# Altium schematic sheet Sheet1.SchDoc(Sheet1)
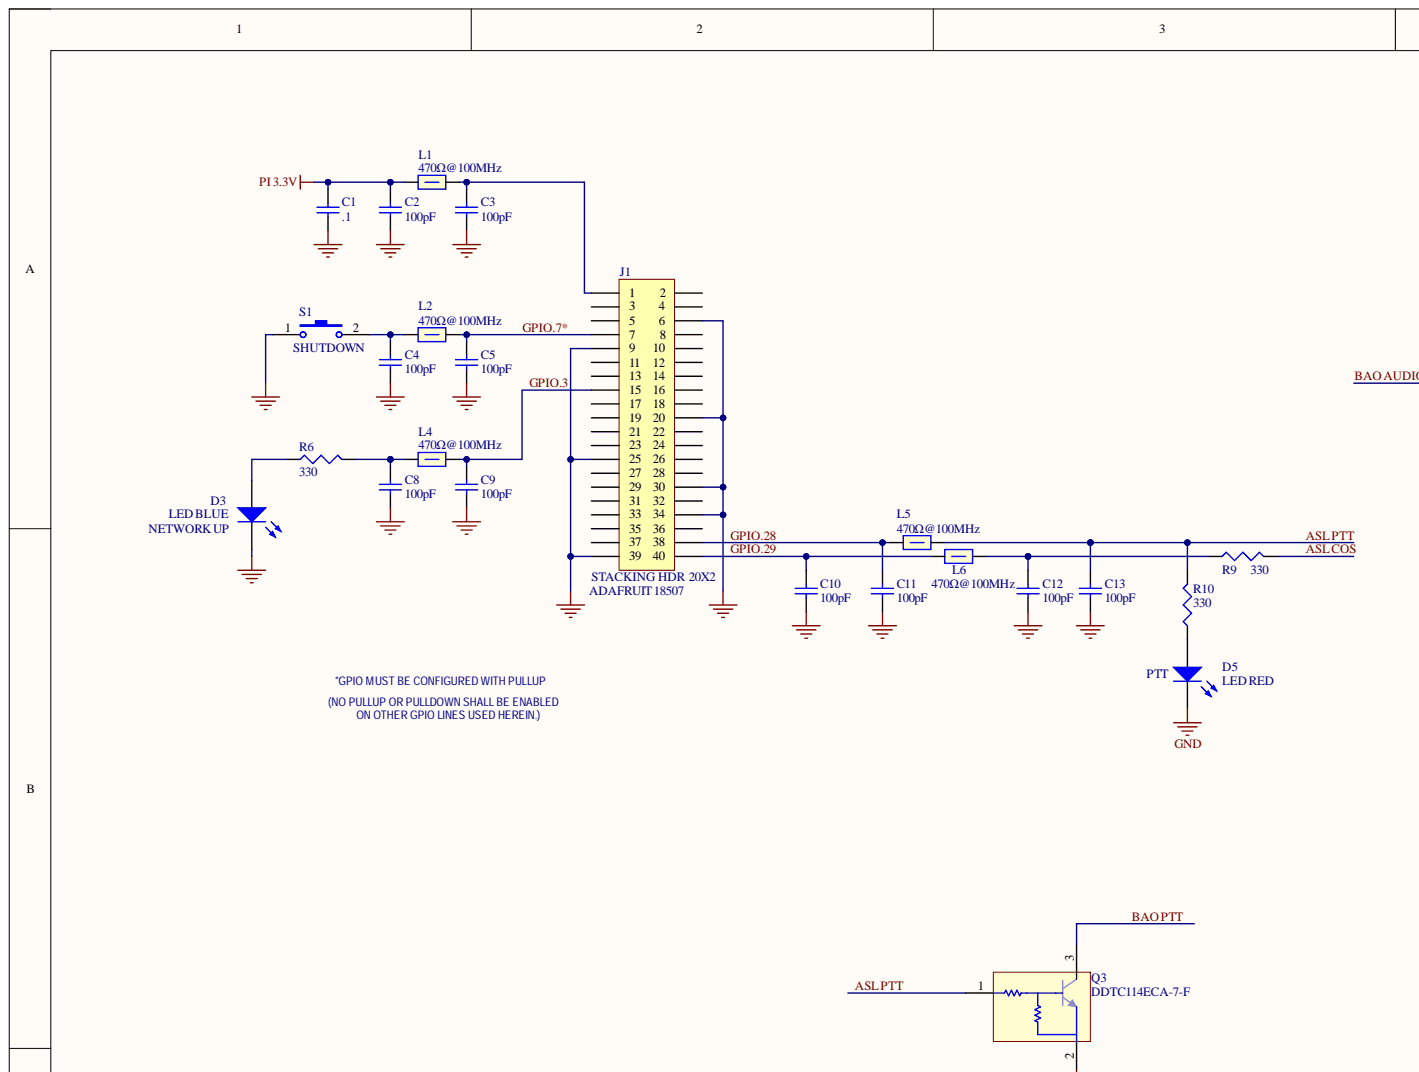
[diagram p0: Sheet1.SchDoc, top left]
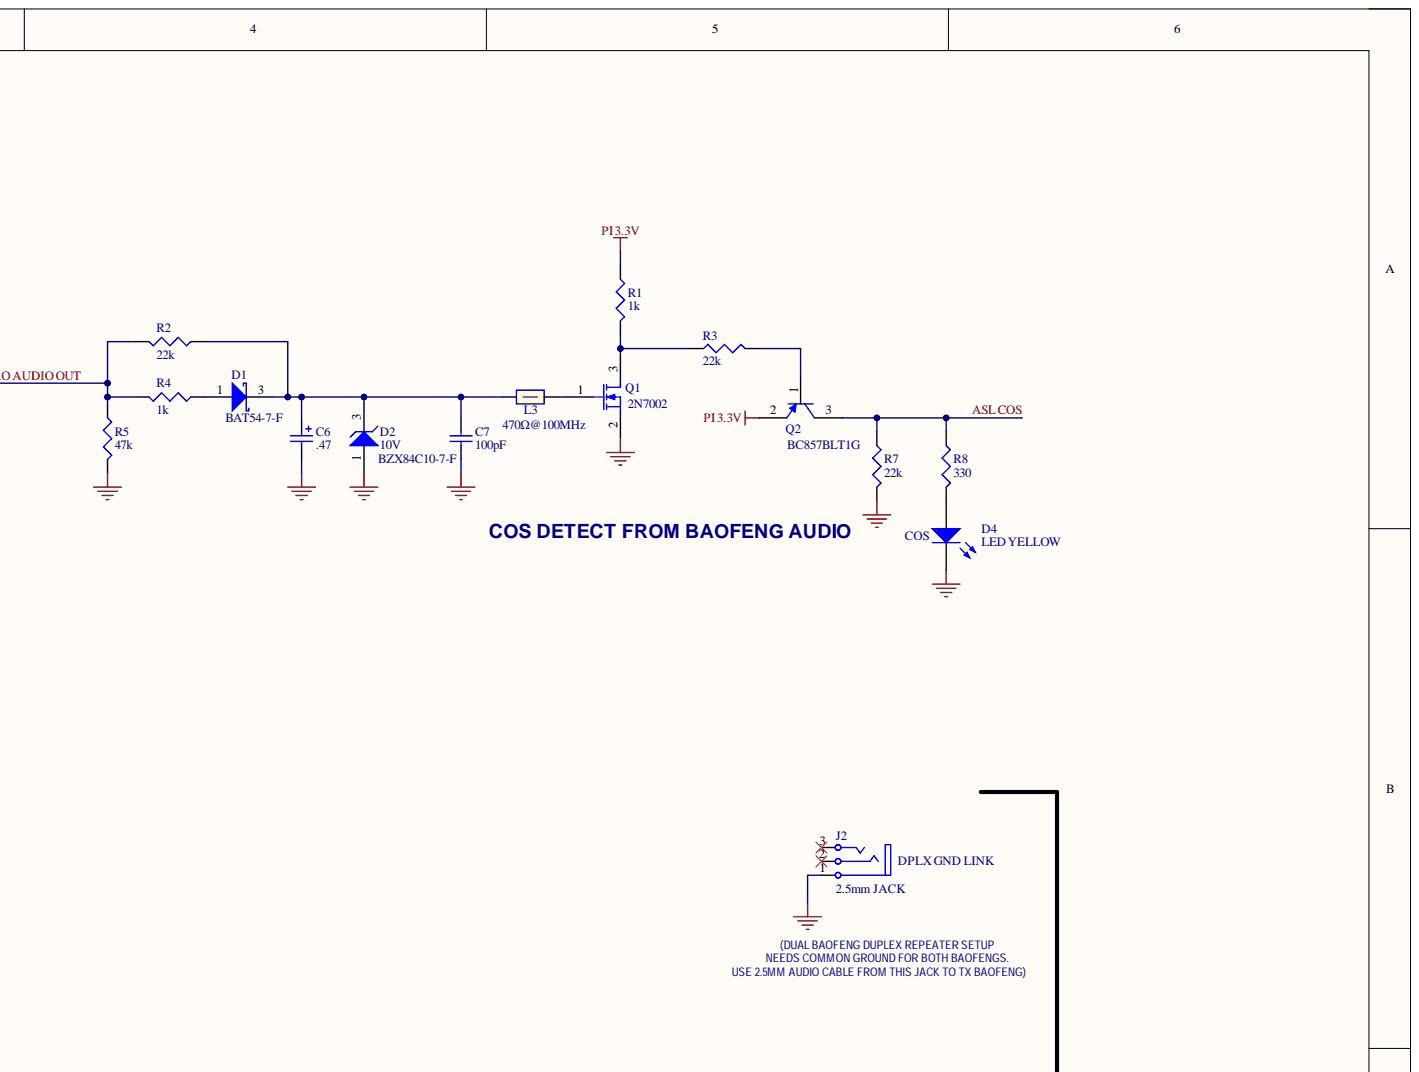
[diagram p1: Sheet1.SchDoc, top right]
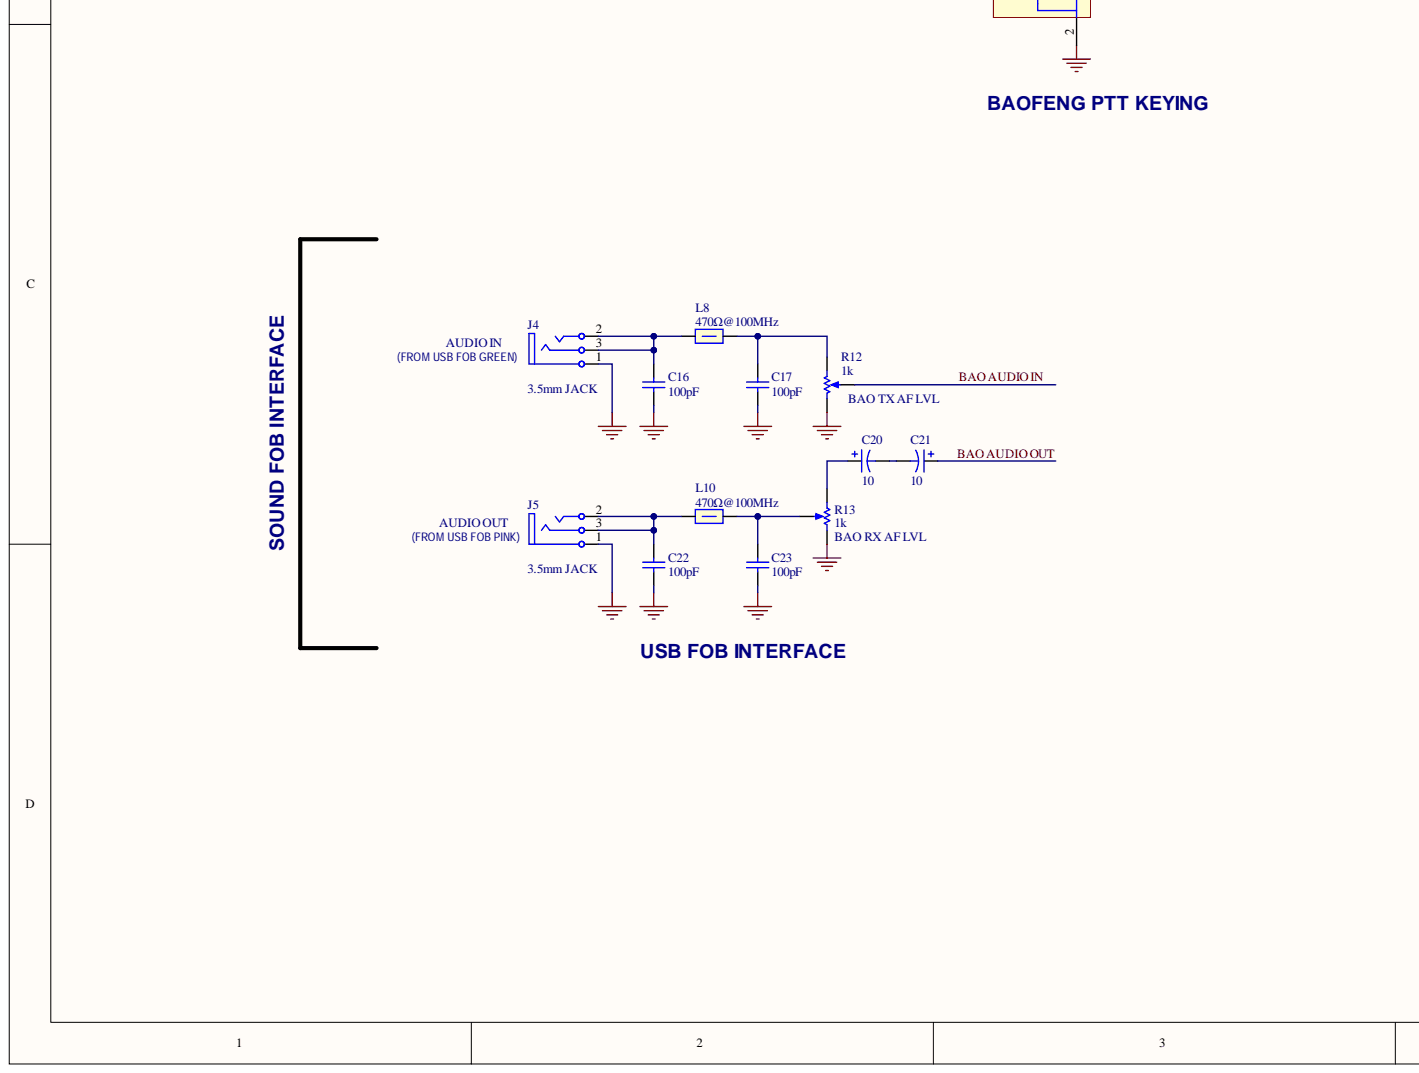
[diagram p2: Sheet1.SchDoc, bottom left]
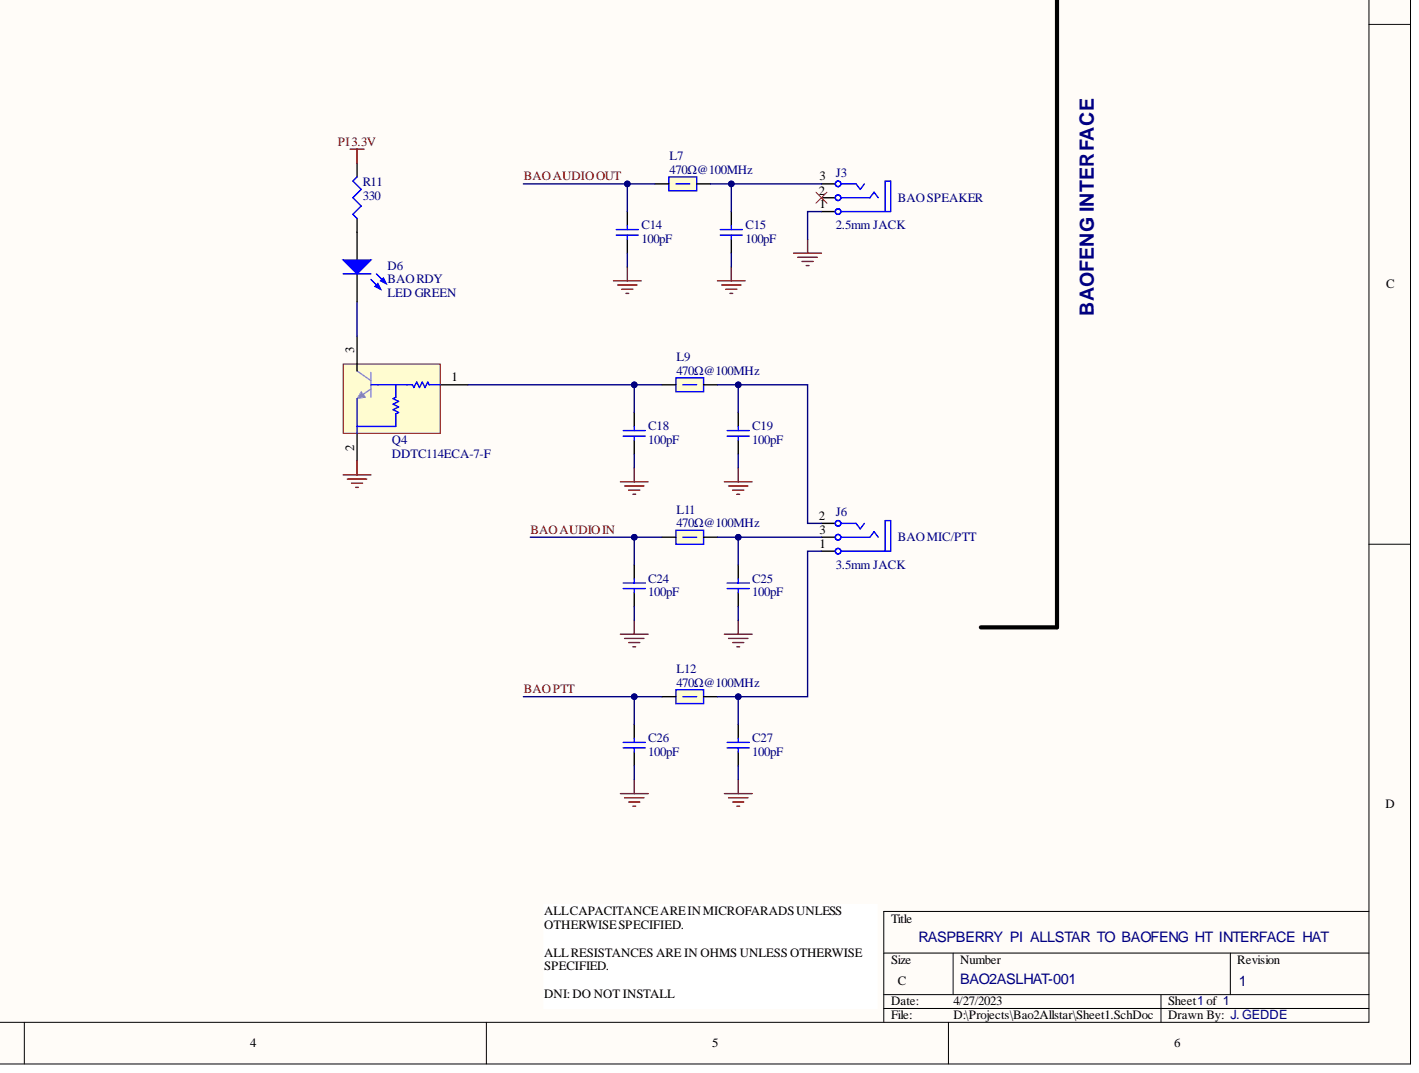
[diagram p3: Sheet1.SchDoc, bottom right]

## Parts
C1  value .1  [C0805_IPC]  (hidden comment: Cap)
C2  value 100pF  [C0805_IPC]  (hidden comment: Cap)
C3  value 100pF  [C0805_IPC]  (hidden comment: Cap)
C4  value 100pF  [C0805_IPC]  (hidden comment: Cap)
C5  value 100pF  [C0805_IPC]  (hidden comment: Cap)
C6  value .47  [Kemet B Case]  (hidden comment: Cap Pol2)
C7  value 100pF  [C0805_IPC]  (hidden comment: Cap)
C8  value 100pF  [C0805_IPC]  (hidden comment: Cap)
C9  value 100pF  [C0805_IPC]  (hidden comment: Cap)
C10  value 100pF  [C0805_IPC]  (hidden comment: Cap)
C11  value 100pF  [C0805_IPC]  (hidden comment: Cap)
C12  value 100pF  [C0805_IPC]  (hidden comment: Cap)
C13  value 100pF  [C0805_IPC]  (hidden comment: Cap)
C14  value 100pF  [C0805_IPC]  (hidden comment: Cap)
C15  value 100pF  [C0805_IPC]  (hidden comment: Cap)
C16  value 100pF  [C0805_IPC]  (hidden comment: Cap)
C17  value 100pF  [C0805_IPC]  (hidden comment: Cap)
C18  value 100pF  [C0805_IPC]  (hidden comment: Cap)
C19  value 100pF  [C0805_IPC]  (hidden comment: Cap)
C20  value 10  [EDH106M016A9BAA]
C21  value 10  [EDH106M016A9BAA]
C22  value 100pF  [C0805_IPC]  (hidden comment: Cap)
C23  value 100pF  [C0805_IPC]  (hidden comment: Cap)
C24  value 100pF  [C0805_IPC]  (hidden comment: Cap)
C25  value 100pF  [C0805_IPC]  (hidden comment: Cap)
C26  value 100pF  [C0805_IPC]  (hidden comment: Cap)
C27  value 100pF  [C0805_IPC]  (hidden comment: Cap)
D1  PN: BAT54-7-F  [SOT23-3]
D2  10V  PN: BZX84C10-7-F  [SOT23-3]
D3  NETWORK UP  value LED BLUE  [APT2012 BLUE]
D4  COS  value LED YELLOW  [APT2012 YELLOW]
D5  PTT  value LED RED  [APT2012 red]
D6  BAO RDY  value LED GREEN  [APT2012 green]
J1  STACKING HDR 20X2  Manufacturer: ADAFRUIT  PN: 18507  [adafruit 18507]
J2  DPLX GND LINK  value 2.5mm JACK  [SJ-2509N]
J3  BAO SPEAKER  value 2.5mm JACK  [SJ-2509N]
J4  AUDIO IN  value 3.5mm JACK  [SJ1-3523NG]
J5  AUDIO OUT  value 3.5mm JACK  [SJ1-3523NG]
J6  BAO MIC/PTT  value 3.5mm JACK  [SJ1-3523NG]
L1  value 470Ω@100MHz  [R0603_IPC]  (hidden comment: Ferrite Bead)
L2  value 470Ω@100MHz  [R0603_IPC]  (hidden comment: Ferrite Bead)
L3  value 470Ω@100MHz  [R0603_IPC]  (hidden comment: Ferrite Bead)
L4  value 470Ω@100MHz  [R0603_IPC]  (hidden comment: Ferrite Bead)
L5  value 470Ω@100MHz  [R0603_IPC]  (hidden comment: Ferrite Bead)
L6  value 470Ω@100MHz  [R0603_IPC]  (hidden comment: Ferrite Bead)
L7  value 470Ω@100MHz  [R0603_IPC]  (hidden comment: Ferrite Bead)
L8  value 470Ω@100MHz  [R0603_IPC]  (hidden comment: Ferrite Bead)
L9  value 470Ω@100MHz  [R0603_IPC]  (hidden comment: Ferrite Bead)
L10  value 470Ω@100MHz  [R0603_IPC]  (hidden comment: Ferrite Bead)
L11  value 470Ω@100MHz  [R0603_IPC]  (hidden comment: Ferrite Bead)
L12  value 470Ω@100MHz  [R0603_IPC]  (hidden comment: Ferrite Bead)
Q1  PN: 2N7002  [SOT23-3]  (hidden comment: MOSFET-N)
Q2  PN: BC857BLT1G  [SOT23-3]  (hidden comment: PNP)
Q3  DTC114  PN: DDTC114ECA-7-F  [SOT23-3]
Q4  DTC114  PN: DDTC114ECA-7-F  [SOT23-3]
R1  value 1k  [R0805_IPC]  (hidden comment: Res3)
R2  value 22k  [R0805_IPC]  (hidden comment: Res3)
R3  value 22k  [R0805_IPC]  (hidden comment: Res3)
R4  value 1k  [R0805_IPC]  (hidden comment: Res3)
R5  value 47k  [R0805_IPC]  (hidden comment: Res3)
R6  value 330  [R0805_IPC]  (hidden comment: Res3)
R7  value 22k  [R0805_IPC]  (hidden comment: Res3)
R8  value 330  [R0805_IPC]  (hidden comment: Res3)
R9  value 330  [R0805_IPC]  (hidden comment: Res3)
R10  value 330  [R0805_IPC]  (hidden comment: Res3)
R11  value 330  [R0805_IPC]  (hidden comment: Res3)
R12  BAO TX AF LVL  value 1k  [BOURNS 3386F]
R13  BAO RX AF LVL  value 1k  [BOURNS 3386F]
S1  SHUTDOWN  [PTS645SL43SMTR92LFS]

## Nets
GND: C1.1 C2.1 C3.1 C4.1 C5.1 C6.2 C7.1 C8.1 C9.1 C10.1 C11.1 C12.1 C13.1 C14.1 C15.1 C16.1 C17.1 C18.1 C19.1 C22.1 C23.1 C24.1 C25.1 C26.1 C27.1 D2.1 D3.K D4.K D5.K J1.6 J1.9 J1.20 J1.25 J1.30 J1.34 J1.39 J2.1 J3.1 J4.1 J5.1 Q1.2 Q3.2 Q4.2 R5.2 R7.2 R12.2 R13.2 S1.1
PI 3.3V: C1.2 C2.2 L1.1 Q2.2 R1.1 R11.2
ASL COS: Q2.3 R7.1 R8.1 R9.2
ASL PTT: C13.2 L5.2 Q3.1 R10.2
BAO AUDIO IN: C24.2 L11.1 R12.3
BAO AUDIO OUT: C14.2 C21.1 L7.1 R2.2 R4.2 R5.1
BAO PTT: C26.2 L12.1 Q3.3
GPIO.3: C9.2 J1.15 L4.2
GPIO.7*: C5.2 J1.7 L2.2
GPIO.28: C11.2 J1.38 L5.1
GPIO.29: C10.2 J1.40 L6.1
NetC3_2: C3.2 J1.1 L1.2
NetC4_2: C4.2 L2.1 S1.2
NetC6_1: C6.1 C7.2 D1.3 D2.3 L3.1 R2.1
NetC8_2: C8.2 L4.1 R6.2
NetC12_2: C12.2 L6.2 R9.1
NetC15_2: C15.2 J3.3 L7.2
NetC16_2: C16.2 J4.2 J4.3 L8.1
NetC17_2: C17.2 L8.2 R12.1
NetC18_2: C18.2 L9.1 Q4.1
NetC19_2: C19.2 J6.2 L9.2
NetC20_1: C20.1 R13.1
NetC20_2: C20.2 C21.2
NetC22_2: C22.2 J5.2 J5.3 L10.1
NetC23_2: C23.2 L10.2 R13.3
NetC25_2: C25.2 J6.3 L11.2
NetC27_2: C27.2 J6.1 L12.2
NetD1_1: D1.1 R4.1
NetD3_A: D3.A R6.1
NetD4_A: D4.A R8.2
NetD5_A: D5.A R10.1
NetD6_A: D6.A R11.1
NetD6_K: D6.K Q4.3
NetL3_2: L3.2 Q1.1
NetQ1_3: Q1.3 R1.2 R3.1
NetQ2_1: Q2.1 R3.2

Not connected: J1 2 3 4 5 8 10 11 12 13 14 16 17 18 19 21 22 23 24 26 27 28 29 31 32 33 35 36 37; J2 2 3; J3 2

## Library data of the parts
C1 = Cap: CAP CER 0.1UF 50V X7R 0805; Manufacturer: Kemet; PN: C0805C104M5RAC7025; Component Kind: Standard; Library Name: Miscellaneous Devices.IntLib
C2-C5 C7-C19 C22-C27 = Cap: CAP CER 100PF 100V C0G/NP0 0805; Manufacturer: Kemet; PN: C0805C101J1GAC7800; Component Kind: Standard; Library Name: Miscellaneous Devices.IntLib
C6 = Cap Pol2: Polarized Capacitor (Axial); Manufacturer: Kemet; PN: T495B474K035ATE2K2; Component Kind: Standard; Library Name: Miscellaneous Devices.IntLib
C20 C21 = Cap Pol1: CAP ALUM 10UF 20% 16V SMD; Manufacturer: Kemet; Component Kind: Standard; Library Name: Miscellaneous Devices.IntLib; PN: EDH106M016A9BAA
D1 = Diode 10TQ035: DIODE SCHOTTKY 30V 200MA SOT23-3; Manufacturer: Diodes Inc.; Component Kind: Standard; Library Name: Miscellaneous Devices.IntLib
D2 = D Zener: DIODE ZENER 10V 300MW SOT23-3; Manufacturer: Diodes Inc.; Component Kind: Standard; Library Name: Miscellaneous Devices.IntLib
D3 = LED0: LED BLUE CLEAR 2012 SMD; Manufacturer: Kingbright; PN: APTD2012LQBC/D; Component Kind: Standard; Library Name: Miscellaneous Devices.IntLib
D4 = LED0: LED YELLOW CLEAR 2012 SMD; Manufacturer: Kingbright; PN: APTD2012LSYCK; Component Kind: Standard; Library Name: Miscellaneous Devices.IntLib
D5 = LED0: LED RED CLEAR 2012 SMD; Manufacturer: Kingbright; PN: APTD2012LSURCK; Component Kind: Standard; Library Name: Miscellaneous Devices.IntLib
D6 = LED0: LED GREEN CLEAR 2012 SMD; Manufacturer: Kingbright; PN: APTD2012LCGCK; Component Kind: Standard; Library Name: Miscellaneous Devices.IntLib
J1 = Header 20X2: 2X20 PIN STACKING HEADER; Component Kind: Standard; Library Name: Miscellaneous Connectors.IntLib
J2 J3 = Phonejack3: CONN JACK STEREO 2.5MM R/A; Manufacturer: CUI; Component Kind: Standard; Library Name: Miscellaneous Connectors.IntLib; PN: SJ-2509N
J4-J6 = Phonejack3: CONN JACK STEREO 3.5MM R/A; Manufacturer: CUI; Component Kind: Standard; Library Name: Miscellaneous Connectors.IntLib; PN: SJ1-3523NG
L1-L12 = Ferrite Bead: 470ohms@100MHZ 0603 ferrite bead; Manufacturer: Wurth Elelctric; PN: 742863147; Component Kind: Standard; Library Name: Ferrite Bead.SchLib
Q1 = MOSFET-N: MOSFET N-CH 60V 115MA SOT-23; Manufacturer: OnSemi; Component Kind: Standard; Library Name: Miscellaneous Devices.IntLib
Q2 = PNP: TRANS PNP 45V 0.1A SOT23-3; Manufacturer: OnSemi; Component Kind: Standard; Library Name: Miscellaneous Devices.IntLib
Q3 Q4 = DTC114: TRANS PREBIAS NPN 200MW SOT23-3; Manufacturer: Diodes Inc.; Component Kind: Standard; Library Name: transistors.SchLib
R1 R4 = Res3: RES SMD 1K OHM 1% 1/8W 0805; Manufacturer: Panasonic; PN: ERJ-6ENF1001V; Component Kind: Standard; Library Name: Miscellaneous Devices.IntLib
R2 R3 R7 = Res3: RES SMD 22K OHM 5% 1/8W 0805; Manufacturer: Panasonic; PN: ERJ-6GEYJ223V; Component Kind: Standard; Library Name: Miscellaneous Devices.IntLib
R5 = Res3: RES SMD 47K OHM 1% 1/8W 0805; Manufacturer: Panasonic; PN: ERJ-6ENF4702V; Component Kind: Standard; Library Name: Miscellaneous Devices.IntLib
R6 R8-R11 = Res3: RES SMD 330 OHM 1% 1/8W 0805; Manufacturer: Panasonic; PN: ERJ-6ENF3300V; Component Kind: Standard; Library Name: Miscellaneous Devices.IntLib
R12 R13 = RPot: TRIMMER 1k OHM 0.5W PC PIN TOP; Manufacturer: Bourns; PN: 3386F-1-102LF; Component Kind: Standard; Library Name: Miscellaneous Devices.IntLib
S1 = SW-PB: SWITCH TACTILE SPST-NO 0.05A 12V; Manufacturer: C&K; PN: PTS645SL43SMTR92 LFS; Component Kind: Standard; Library Name: Miscellaneous Devices.IntLib
